# Altium schematic sheet TOP-LEVEL-GDB.SchDoc(TOP-LEVEL-GDB)
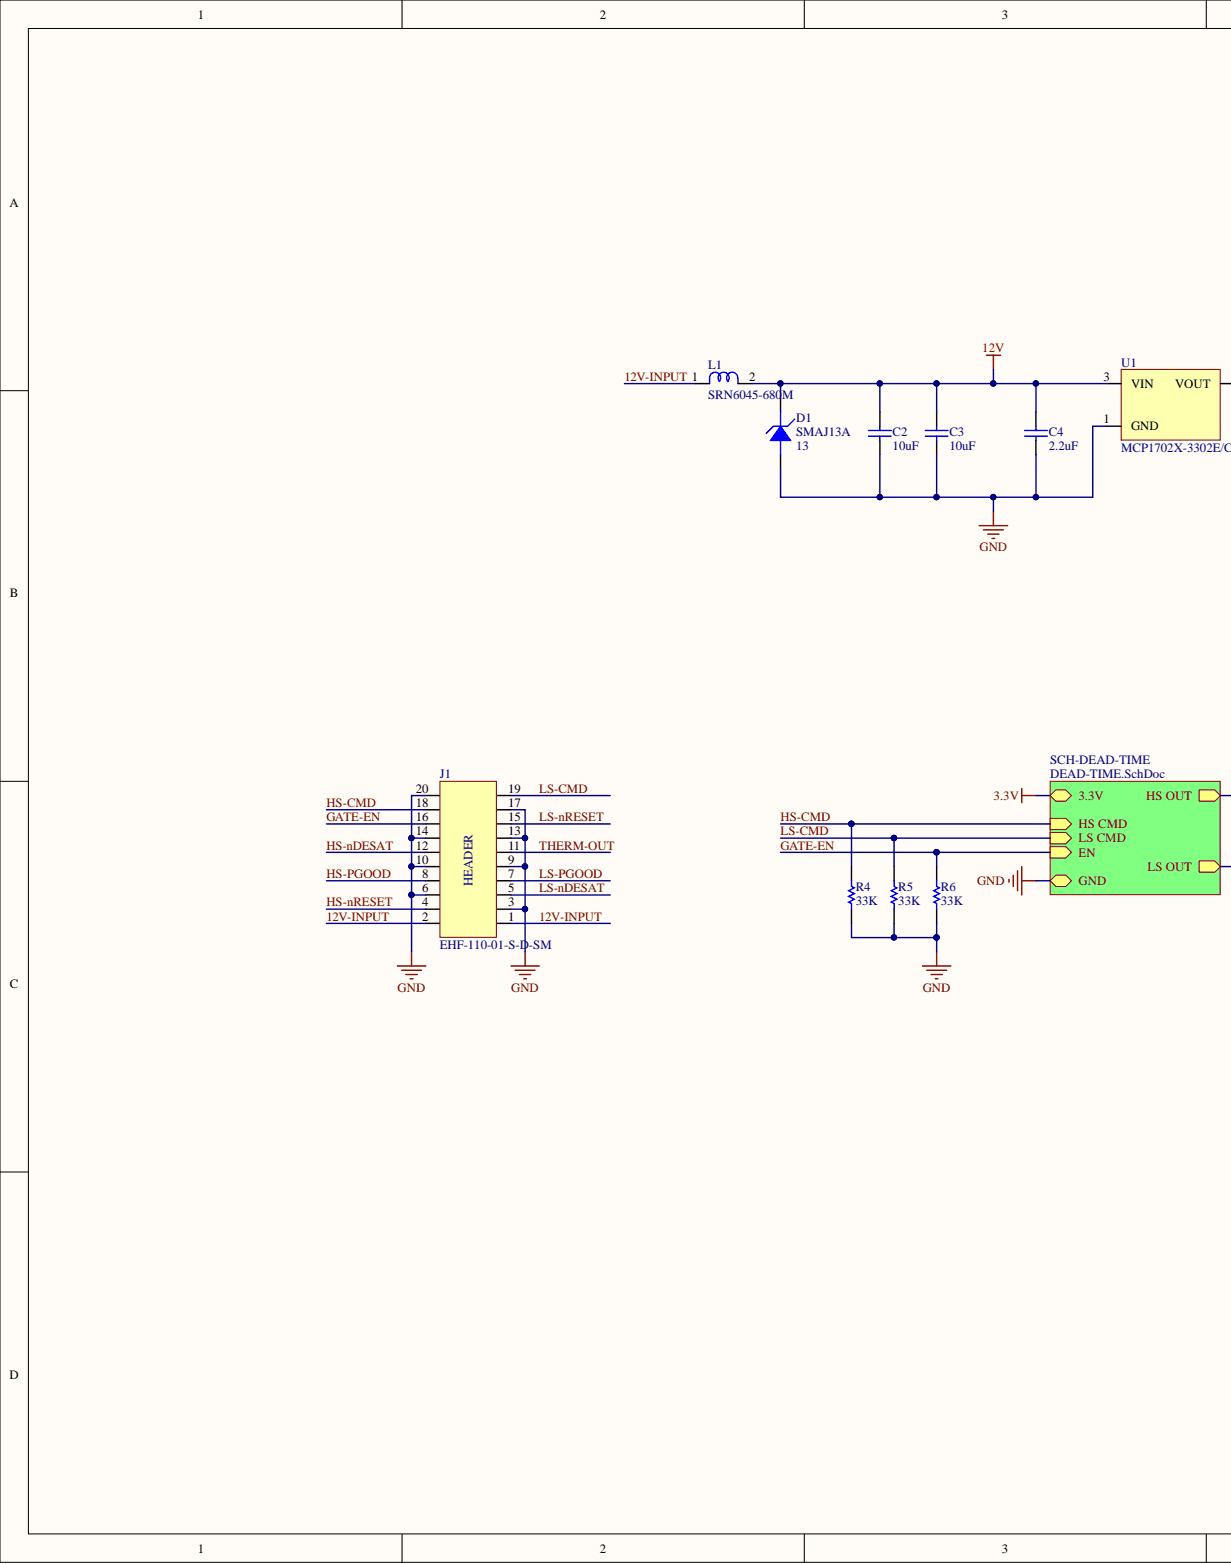
[diagram p0: TOP-LEVEL-GDB.SchDoc, left]
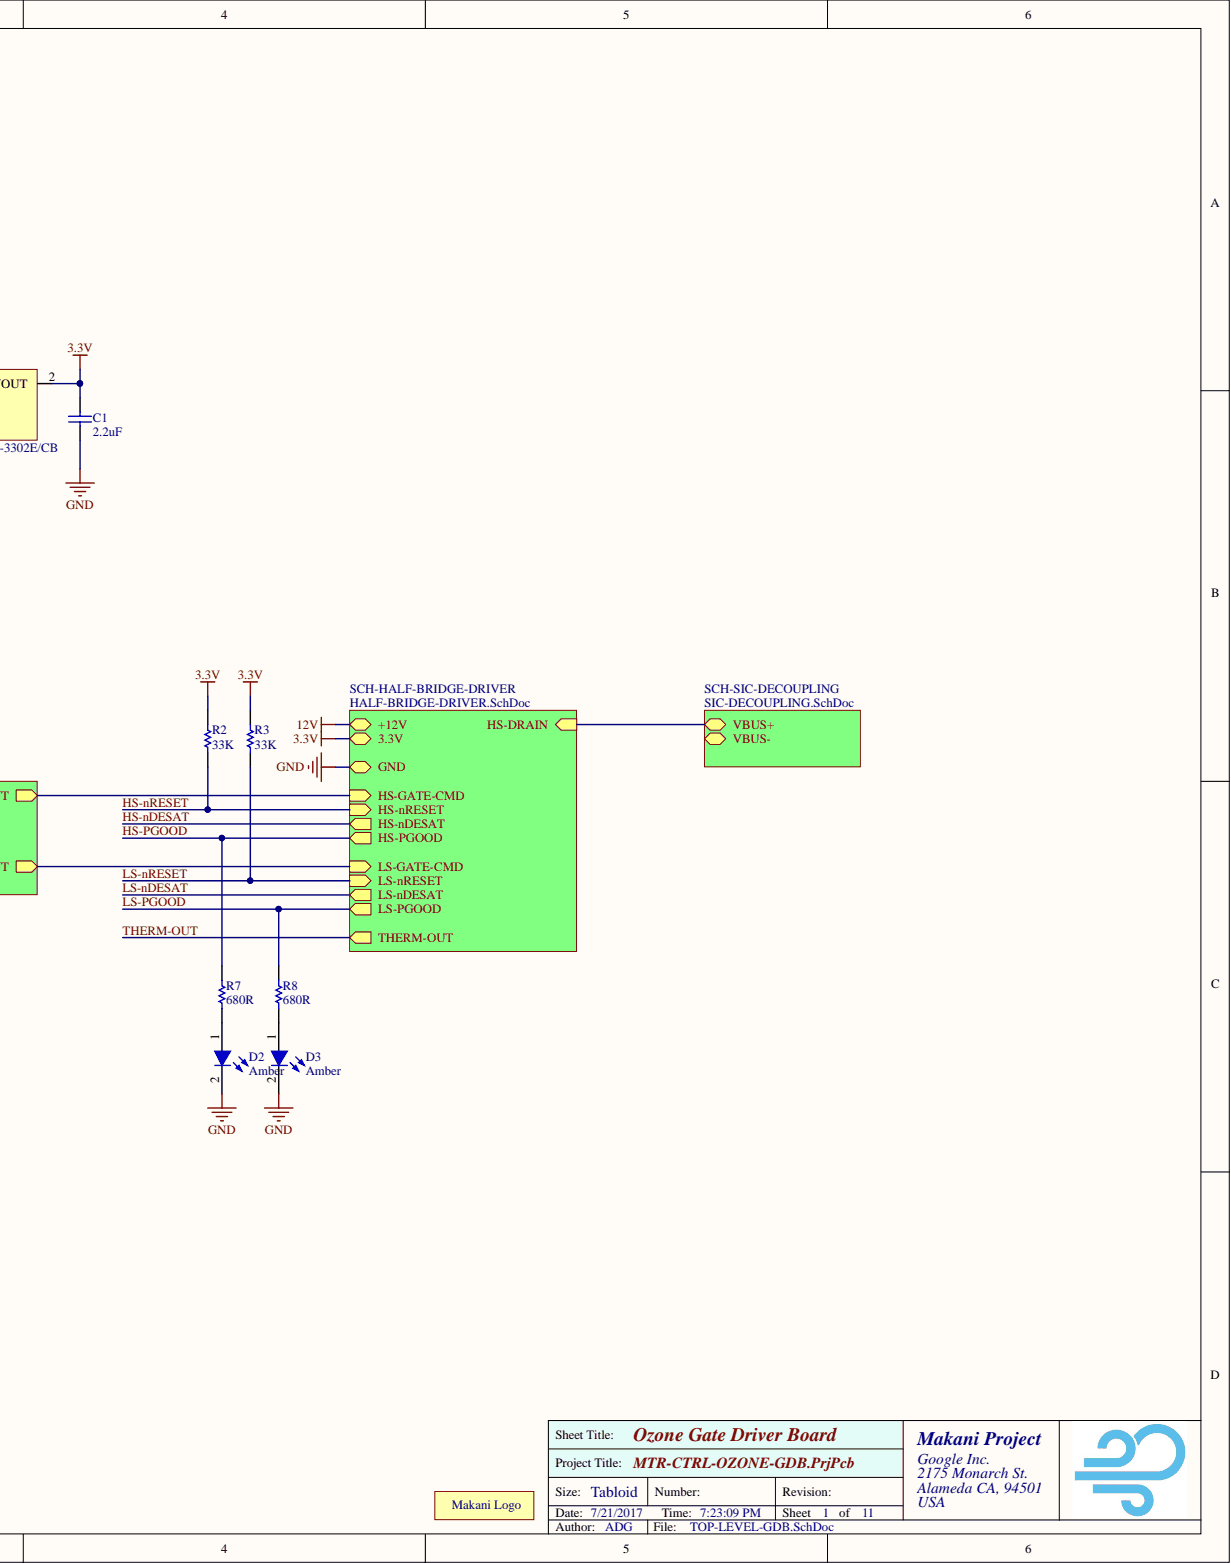
[diagram p1: TOP-LEVEL-GDB.SchDoc, right]

## Parts
C1  value 2.2uF  [CAPC0603(1608)55_N]  (hidden comment: CAP 2.2uF 16V 0603(1608))
C2  value 10uF  [CAPC1206(3216)130_N]  (hidden comment: CAP 10uF 16V 1206(3216))
C3  value 10uF  [CAPC1206(3216)130_N]  (hidden comment: CAP 10uF 16V 1206(3216))
C4  value 2.2uF  [CAPC0603(1608)55_N]  (hidden comment: CAP 2.2uF 16V 0603(1608))
D1  SMAJ13A  [LT-DO-214AC-SMA]
D2  Color: Amber  [LEDC0603(1608)_M]  (hidden comment: LNJ437W84RA)
D3  Color: Amber  [LEDC0603(1608)_M]  (hidden comment: LNJ437W84RA)
J1  EHF-110-01-S-D-SM  [SAM-EHF-110-01-X-D-SM]
L1  SRN6045-680M  [BRNS-SRN6045]
Logo1  Makani Logo  [MAKANI-LOGO-200MIL]  (no BOM)
R2  value 33K  [RESC0603(1608)_N]  (hidden comment: 33K 1% 0603(1608))
R3  value 33K  [RESC0603(1608)_N]  (hidden comment: 33K 1% 0603(1608))
R4  value 33K  [RESC0603(1608)_N]  (hidden comment: 33K 1% 0603(1608))
R5  value 33K  [RESC0603(1608)_N]  (hidden comment: 33K 1% 0603(1608))
R6  value 33K  [RESC0603(1608)_N]  (hidden comment: 33K 1% 0603(1608))
R7  value 680R  [RESC0603(1608)_N]  (hidden comment: 680R 1% 0603(1608))
R8  value 680R  [RESC0603(1608)_N]  (hidden comment: 680R 1% 0603(1608))
U1  MCP1702X-3302E/CB  [MCP-SOT-23A-CB]

## Nets
3.3V: C1.2 R2.2 R3.2 U1.2
12V: C2.1 C3.1 C4.2 D1.1 L1.2 U1.3
GND: C1.1 C2.2 C3.2 C4.1 D1.2 D2.2 D3.2 J1.3 J1.6 J1.9 J1.10 J1.13 J1.14 J1.17 J1.20 R4.1 R5.1 R6.1 U1.1
12V-INPUT: J1.1 J1.2 L1.1
GATE-EN: J1.16 R6.2
HS-CMD: J1.18 R4.2
HS-nDESAT: J1.12
HS-nRESET: J1.4 R2.1
HS-PGOOD: J1.8 R7.2
LS-CMD: J1.19 R5.2
LS-nDESAT: J1.5
LS-nRESET: J1.15 R3.1
LS-PGOOD: J1.7 R8.2
THERM-OUT: J1.11
NetD2_1: D2.1 R7.1
NetD3_1: D3.1 R8.1
N00218:
N00219:
N00220:
N00221:

## Library data of the parts
C1 C4 = CMP-0002-02649-5: CAP 2.2uF 16V ±10% 0603 (1608 Metric) Thickness 0.55mm SMD; Tolerance: ±20%; Temp Range: -55°C ~ 85°C; Mfg P/N: CL10A225KO8NNNC; Mfg: SAMSUNG; GPN: 150-00826-00; Case-Metric: 1608; Case-EIA: 603; Technology: SMT; Supplier 1 P/N: 1276-1040-1-ND; Supplier 1: DigiKey; Rated Voltage: 16 V; Max Thickness: 0.55 mm; Component Kind: Standard; Library Name: Makani Vault(migrated)
C2 C3 = CMP-0002-04302-4: CAP 10uF 16V ±10% 1206 (3216 Metric) Thickness 1.3mm SMD; Tolerance: ±20%; Mfg P/N: CL31B106KOHNNNE; Mfg: SAMSUNG; GPN: 150-00770-00; Case-Metric: 3216; Case-EIA: 1206; Technology: SMT; Supplier 1 P/N: 1276-1082-1-ND; Supplier 1: DigiKey; Rated Voltage: 16 V; Max Thickness: 1.3 mm; Component Kind: Standard; Library Name: Makani Vault(migrated)
D1 = CMP-0156-00066-3: 13V, 400W, Transient Voltage Suppression Diode; Reverse Standoff Voltage: 13; Reverse Leakage: 1; Power: 400; Peak Pulse Current: 18.6; Mfg: Littelfuse, Inc.; GPN: 430-00139-00; Breakdown Voltage: 14.4; Supplier 1 P/N: SMAJ13ALFCT-ND; Supplier 1: DigiKey; Mfg P/N: SMAJ13A; HelpURL: http://www.littelfuse.com/~/media/Electronics/Datasheets/TVS_Diodes/Littelfuse_TVS_Diode_SMAJ_Datasheet.pdf.pdf; Component Kind: Standard; Library Name: Makani Vault(migrated)
D2 D3 = CMP-0004-00010-4: LED TSS AMBER 0603(1608); Test Current: 5; Mfg: PANASONIC; GPN: 370-00134-00; Forward Voltage: 1.95; Brightness: 25; Supplier 1 P/N: LNJ437W84RACT-ND; Supplier 1: DigiKey; Mfg P/N: LNJ437W84RA; HelpURL: http://www.semicon.panasonic.co.jp/en/products/detail/?cat=CEB7000&part=LNJ437W84RA; Component Kind: Standard; Library Name: Makani Vault(migrated)
J1 = CMP-0145-00030-6: 50mil 20pos Vertical SMD IDC Ejector Header; Positions: 20; Plating: 76um Gold; Mfg: Samtec Inc.; Locking: Yes; GPN: 210-02878-00; Pitch: 50mil; Orientation: Vertical; Mounting: SMD; Mfg P/N: EHF-110-01-S-D-SM; HelpURL: http://suddendocs.samtec.com/catalog_english/ehf.pdf; Component Kind: Standard; Library Name: Makani Vault(migrated)
L1 = CMP-0117-00107-3: Semi-shielded Power Inductors; Value: 68uH; Tolerance: 20; Temp Range: -40 to 125; Mfg: BOURNS, INC.; Isat: 1; Irms: 0.9; GPN: 180-00458-00; DCR: 0.351; Supplier 1 P/N: SRN6045-680MCT-ND; Supplier 1: DigiKey; Mfg P/N: SRN6045-680M; HelpURL: http://www.bourns.com/data/global/pdfs/SRN6045.pdf; Component Kind: Standard; Library Name: Makani Vault(migrated)
Logo1 = CMP-0014-00000-2: Layer: Silk; Size: 200mil; Description: Makani Logo; Library Name: Makani Vault(migrated)
R2-R6 = CMP-0001-00619-5: 33K 0.1W 1% 0603 (1608 Metric)  SMD; Mfg P/N: ERJ3EKF3302V; Mfg: PANASONIC; GPN: 470-00147-00; Case-Metric: 1608; Case-EIA: 0603; Tolerance: 1%; Technology: SMT; Supplier 1 P/N: P33.0KHCT-ND; Supplier 1: DigiKey; Power: 0.1 W; Component Kind: Standard; Library Name: Makani Vault(migrated)
R7 R8 = CMP-0001-00389-5: 680R 0.1W 1% 0603 (1608 Metric)  SMD; Mfg P/N: ERJ3EKF6800V; Mfg: PANASONIC; GPN: 470-02228-00; Case-Metric: 1608; Case-EIA: 0603; Tolerance: 1%; Technology: SMT; Supplier 1 P/N: P680HCT-ND; Supplier 1: DigiKey; Power: 0.1 W; Component Kind: Standard; Library Name: Makani Vault(migrated)
U1 = CMP-0104-00045-3: 250 mA Low Quiescent Current LDO Regulator; Output Voltage: 3.3; Output Current: 250; Mfg P/N: MCP1702T-3302E/CB; Mfg: MICROCHIP TECHNOLOGY INC; GPN: 313-01461-00; Supplier 1 P/N: MCP1702T-3302E/CBCT-ND; Supplier 1: DigiKey; HelpURL: http://ww1.microchip.com/downloads/en/DeviceDoc/22008E.pdf; Component Kind: Standard; Library Name: Makani Vault(migrated)
